# Altium schematic sheet Isolation2.SchDoc
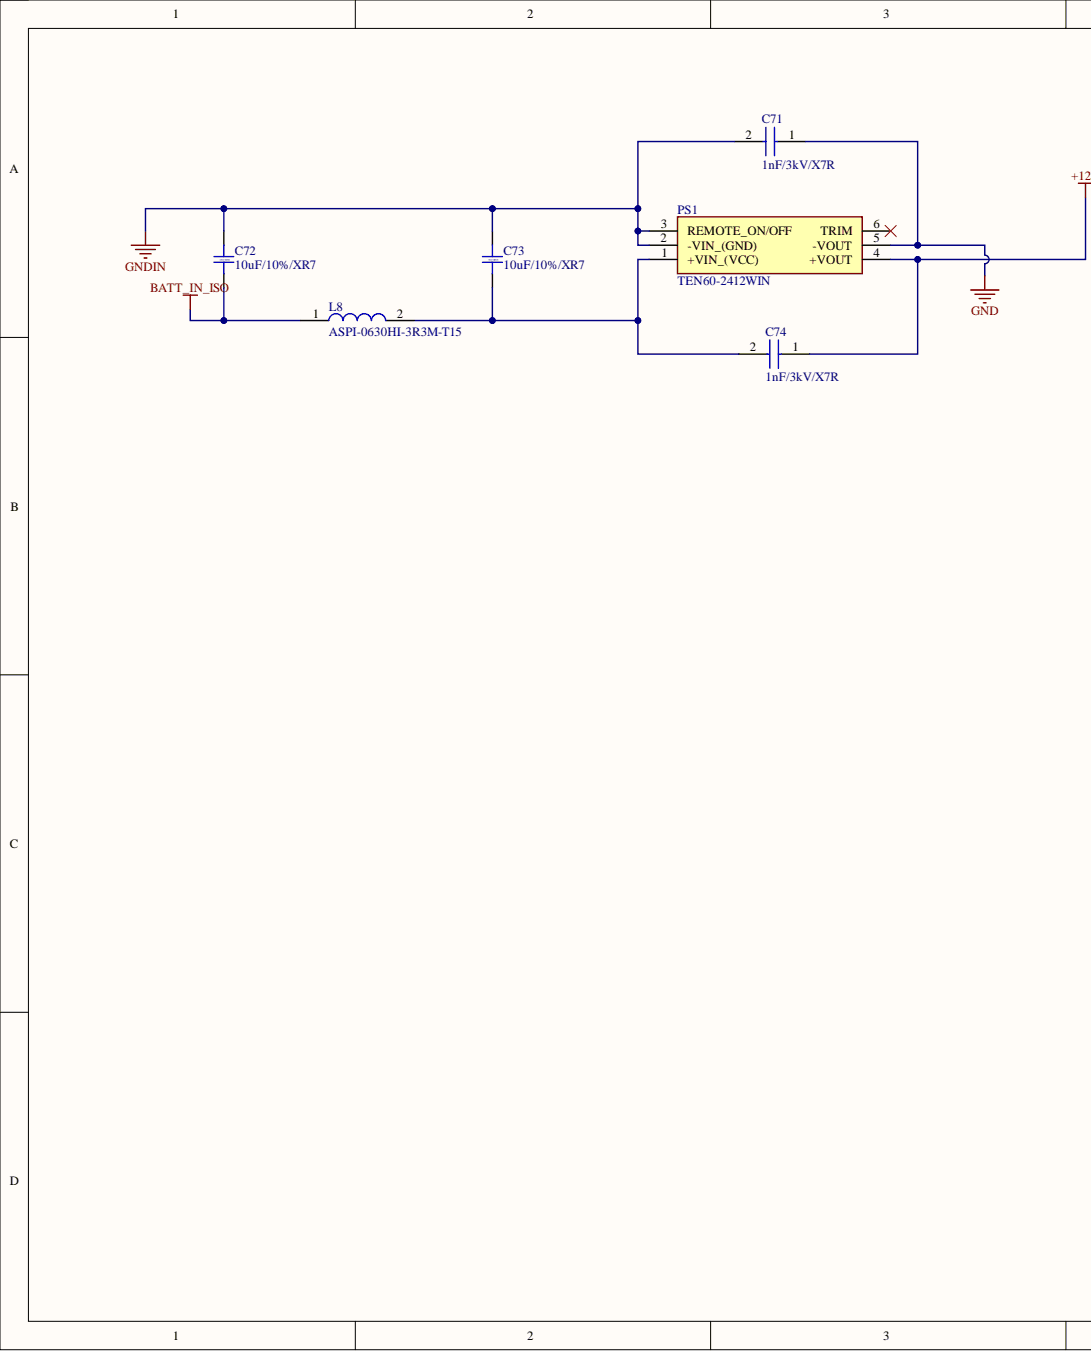
[diagram p0: Isolation2.SchDoc, left]
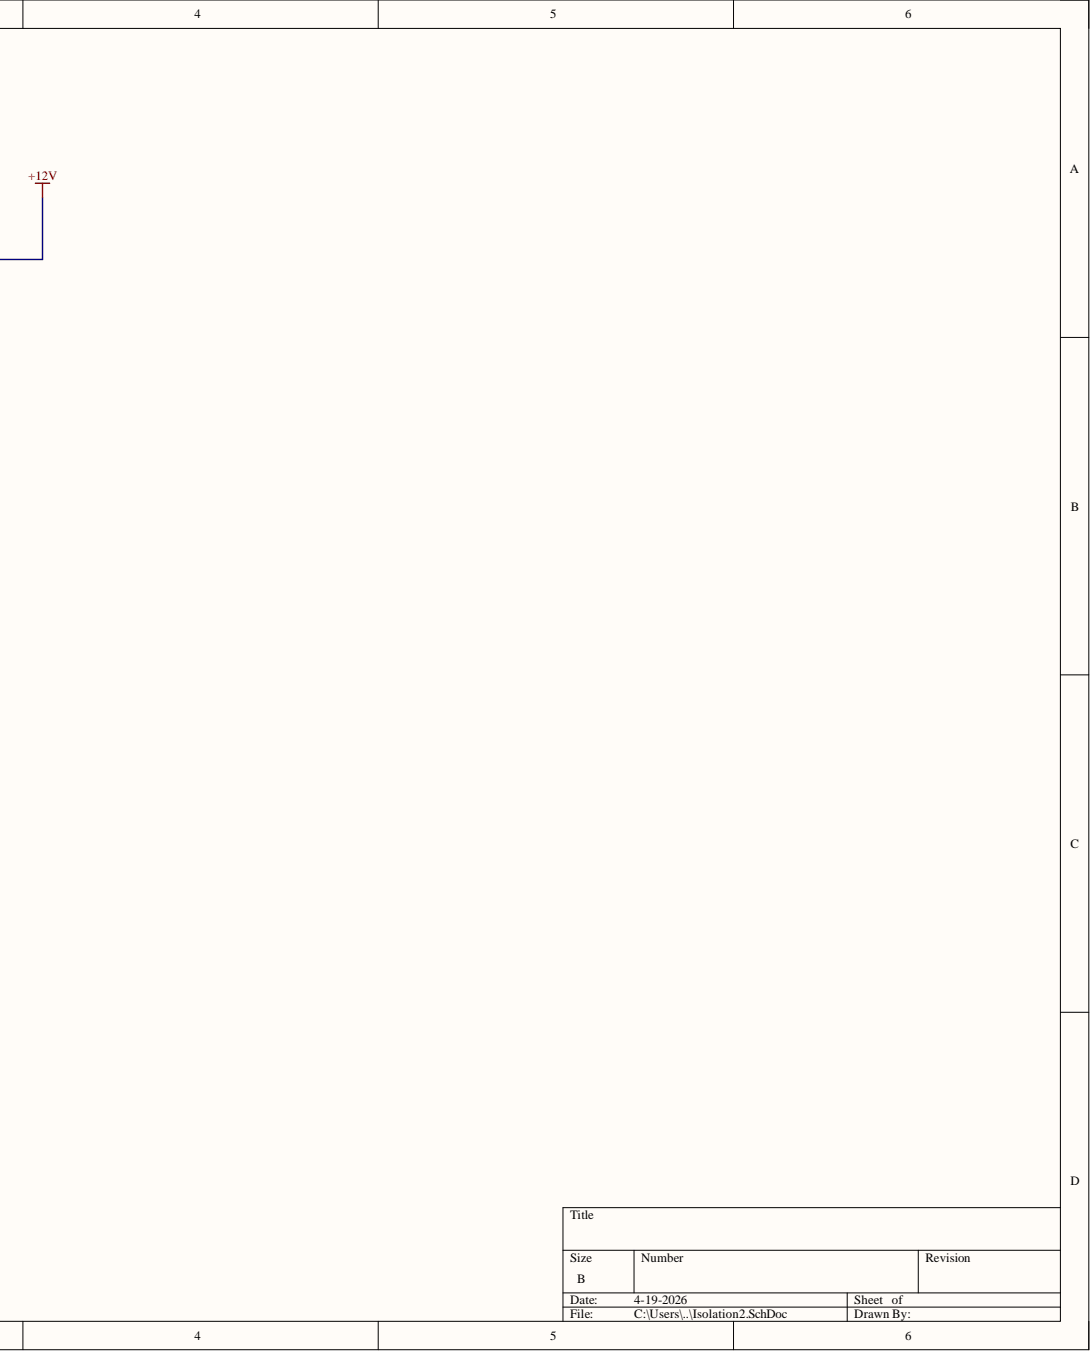
[diagram p1: Isolation2.SchDoc, right]

## Parts
C71  1nF/3kV/X7R  [CAPC4532X270N]
C72  10uF/10%/XR7  [CAP_1210]
C73  10uF/10%/XR7  [CAP_1210]
C74  1nF/3kV/X7R  [CAPC4532X270N]
L8  ASPI-0630HI-3R3M-T15  [ASPI0630HI3R3MT15]
PS1  TEN60-2412WIN  [TEN602412WIN]

## Nets
+12V: C74.1 PS1.4(+VOUT)
BATT_IN_ISO: C72.1 L8.1
GND: C71.1 PS1.5(-VOUT)
GNDIN: C71.2 C72.2 C73.2 PS1.2(-VIN_(GND)) PS1.3(REMOTE_ON/OFF)
NetC73_1: C73.1 C74.2 L8.2 PS1.1(+VIN_(VCC))

Not connected: PS1 6(TRIM)

## Library data of the parts
C71 C74 = HV1812Y102KXHARHV: Capacitor; Manufacturer_Name: Vishay; Arrow Part Number: HV1812Y102KXHARHV; Arrow Price/Stock: https://www.arrow.com/en/products/hv1812y102kxharhv/vishay; Component Kind: Standard; Datasheet Link: https://www.arrow.com/en/products/hv1812y102kxharhv/vishay; Height: 2.7mm; Manufacturer_Part_Number: HV1812Y102KXHARHV; Mouser Part Number: 77-HV1812Y102KXHARHV; Mouser Price/Stock: https://www.mouser.co.uk/ProductDetail/Vishay-Vitramon/HV1812Y102KXHARHV?qs=Ia%2F%252BjdTn2m7ayMfAHVISMQ%3D%3D
C72 C73 = Capacitor-SMD-1210: CL32B106KBVVPLE; Component Kind: Standard; Library Name: STeRG-Passives-Standard.SchLib
L8 = ASPI-0630HI-3R3M-T15: Inductor; Manufacturer_Name: ABRACON; Arrow Part Number: ASPI-0630HI-3R3M-T15; Arrow Price/Stock: https://www.arrow.com/en/products/aspi-0630hi-3r3m-t15/abracon; Component Kind: Standard; Datasheet Link: https://abracon.com/Magnetics/power/ASPI-0630HI.pdf; Height: 3mm; Manufacturer_Part_Number: ASPI-0630HI-3R3M-T15; Mouser Part Number: 815-ASPI0630HI3R3T15; Mouser Price/Stock: https://www.mouser.co.uk/ProductDetail/ABRACON/ASPI-0630HI-3R3M-T15?qs=bWAYEm3xoCYwUcwgROPtBA%3D%3D
PS1 = TEN60-2412WIN: Power Supply; Manufacturer_Name: Traco Power; Component Kind: Standard; Datasheet Link: https://4donline.ihs.com/images/VipMasterIC/IC/TRAC/TRACS00410/TRACS00410-1.pdf?hkey=EF798316E3902B6ED9A73243A3159BB0; Height: 10.7mm; Manufacturer_Part_Number: TEN60-2412WIN
